# Altium schematic sheet 1.WIZ750SR_EVB_PWR_USB.SchDoc
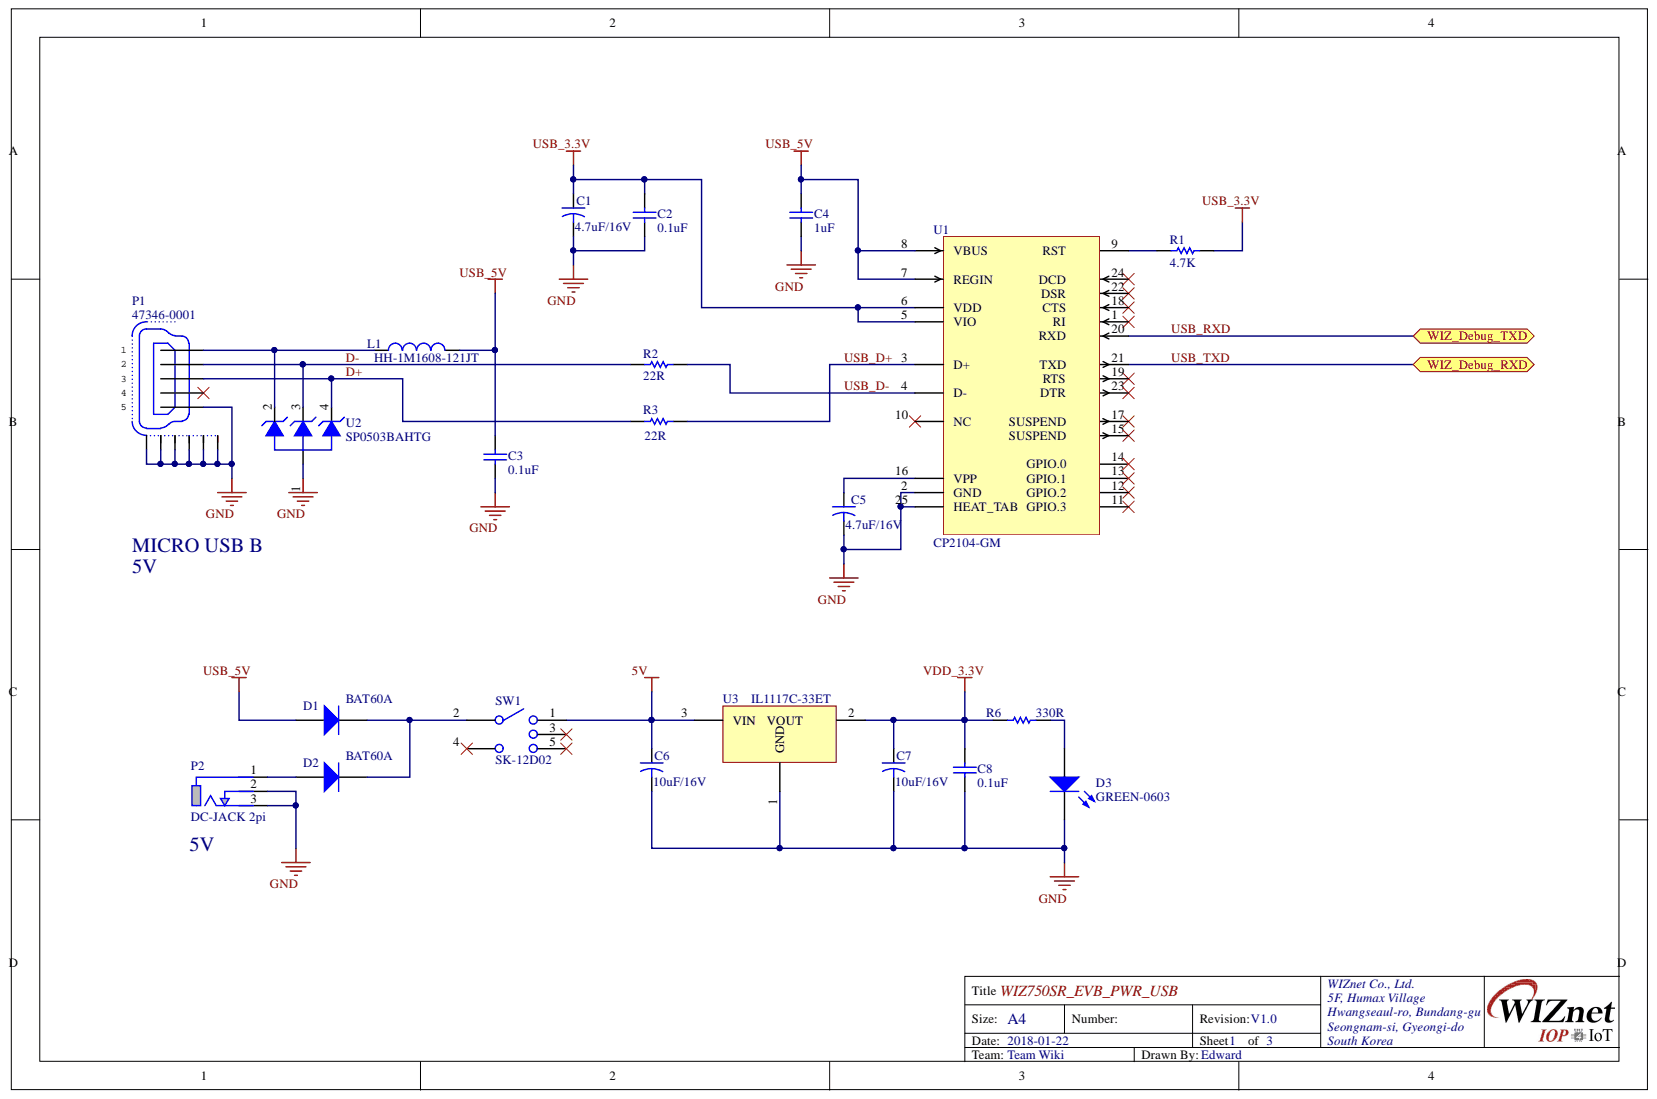
[diagram p0: 1.WIZ750SR_EVB_PWR_USB.SchDoc]

## Parts
C1  4.7uF/16V  [CAP-A Size]
C2  0.1uF  [CAP-0603]
C3  0.1uF  [CAP-0603]
C4  1uF  [CAP-0603]
C5  4.7uF/16V  [CAP-A Size]
C6  10uF/16V  [CAP-A Size]
C7  10uF/16V  [CAP-A Size]
C8  0.1uF  [CAP-0603]
D1  BAT60A  [D-BAT60A/SOD-323]
D2  BAT60A  [D-BAT60A/SOD-323]
D3  GREEN-0603  [LED-0603-RED]
L1  HH-1M1608-121JT  [IND-0603]
P1  47346-0001  [USB-MICRO-B(SMD)]
P2  DC-JACK 2pi  [DC-JACK 2pi]
R1  4.7K  [RES-0603]
R2  22R  [RES-0603]
R3  22R  [RES-0603]
R6  330R  [RES-0603]
SW1  SK-12D02  [SK-12D02]
U1  CP2104-GM  [CP2104_QFN24_PAD]
U2  SP0503BAHTG  [SP0503BAHTG]
U3  IL1117C-33ET  [IL1117C-33ET]

## Ports
WIZ_DEBUG_RXD, WIZ_DEBUG_TXD

## Nets
5V: C6.1 SW1.1 U3.3
GND: C1.2 C2.1 C3.1 C4.1 C5.2 C6.2 C7.2 C8.1 D3.2 P1.5 P1.6 P1.7 P1.8 P1.9 P1.10 P1.11 P2.2 P2.3 U1.2(GND) U1.25(HEAT_TAB) U2.1 U3.1
USB_3.3V: C1.1 C2.2 R1.2 U1.5(VIO) U1.6(VDD)
USB_5V: C3.2 C4.2 D1.2 L1.2 U1.7(REGIN) U1.8(VBUS)
VDD_3.3V: C7.1 C8.2 R6.1 U3.2
D+: P1.3 R3.1 U2.4
D-: P1.2 R2.1 U2.3
USB_D+: R3.2 U1.3(D+)
USB_D-: R2.2 U1.4(D-)
USB_RXD: U1.20 ; port WIZ_DEBUG_TXD
USB_TXD: U1.21 ; port WIZ_DEBUG_RXD
NetC5_1: C5.1 U1.16(VPP)
NetD1_1: D1.1 D2.1 SW1.2
NetD2_2: D2.2 P2.1
NetD3_1: D3.1 R6.2
NetL1_1: L1.1 P1.1 U2.2
NetR1_1: R1.1 U1.9

Not connected: P1 4; SW1 3 4 5; U1 1 10(NC) 11 12 13 14 15 17 18 19 22 23 24

## Library data of the parts
C1 C5-C7 = CAP-A Size: Tantla CAP A Size EIA/IECQ 3216; Component Kind: Standard; Library Name: Scott_lib.IntLib
C2-C4 C8 = CAP-0603: Ceramic CAP 0603(1608); Component Kind: Standard; Library Name: Scott_lib.IntLib
D1 D2 = DIODE-BAT60A: Silicon Schottky Diode; Component Kind: Standard; Library Name: Scott_lib.IntLib
D3 = LED-0603: Component Kind: Standard; Description: LED-0603; Library Name: Scott_lib.IntLib
L1 = IND-0603: Inductor 0603(1608); Component Kind: Standard; Library Name: WIZ750SR.IntLib
P1 = 47346-0001: MICRO USB B Type; Component Kind: Standard; Library Name: Edward.SchLib
R1-R3 R6 = RES-0603: Component Kind: Standard; Description: RES-0603; Library Name: Scott_lib.IntLib
SW1 = SK-12D02: 3 Port SW , SPDT ON-ON , 50V, 0.3A; Component Kind: Standard; Library Name: Edward.IntLib
U1 = CP2104-GM: USB to UART Bridge, SiliconLabs; Component Kind: Standard; RefDes: RefDes; Type: Type; Value: Value; Library Name: Edward.IntLib
U2 = SP0503BAHTG: Little Fuse, Silicon Protection Array Products; Component Kind: Standard; Library Name: Edward.IntLib
U3 = IL1117C-33ET: 1A, 3.3V; Component Kind: Standard; Library Name: Edward.IntLib
P2 = DC-JACK 2pi: Component Kind: Standard; Description: DC-JACK 2pi; Library Name: Scott_lib.IntLib
